# Altium schematic sheet PAGE04_飞机端BMS.SchDoc
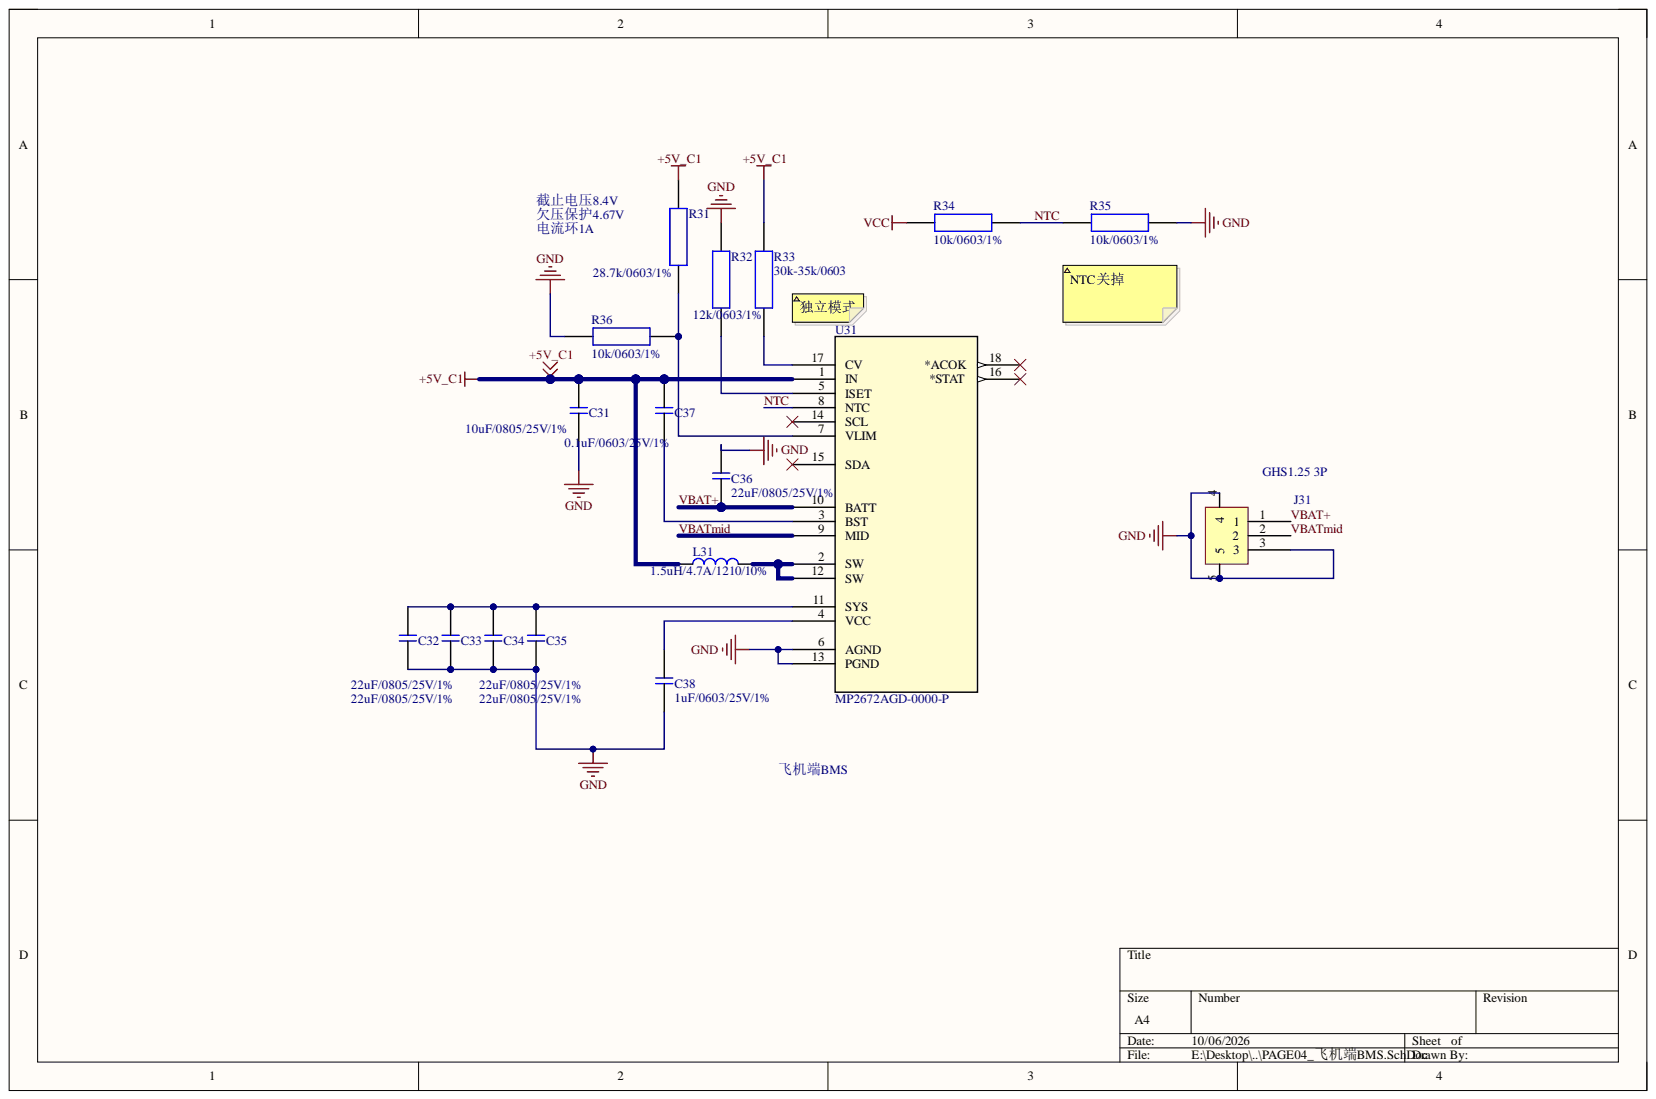
[diagram p0: PAGE04_飞机端BMS.SchDoc]

## Parts
C31  10uF/0805/25V/1%  [0603_ding_C]
C32  22uF/0805/25V/1%  [0603_ding_C]
C33  22uF/0805/25V/1%  [0603_ding_C]
C34  22uF/0805/25V/1%  [0603_ding_C]
C35  22uF/0805/25V/1%  [0603_ding_C]
C36  22uF/0805/25V/1%  [0603_ding_C]
C37  0.1uF/0603/25V/1%  [0603_ding_C]
C38  1uF/0603/25V/1%  [0603_ding_C]
J31  GHS1.25 3P  [SM03B_GHS立式]
L31  1.5uH/4.7A/1210/10%  [1210_ding_C]
R31  28.7k/0603/1%  [0603_ding_R]
R32  12k/0603/1%  [0603_ding_R]
R33  30k-35k/0603  [0603_ding_R]
R34  10k/0603/1%  [0603_ding_R]
R35  10k/0603/1%  [0603_ding_R]
R36  10k/0603/1%  [0603_ding_R]
U31  MP2672AGD-0000-P  [QFN-18_MP2672A_MNP]

## Nets
+5V_C1: C31.2 C37.2 L31.1 R31.2 R33.2 U31.1(IN)
GND: C31.1 C32.1 C33.1 C34.1 C35.1 C36.1 C38.1 J31.3 J31.4 J31.5 R32.2 R35.1 R36.2 U31.6(AGND) U31.13(PGND)
VCC: R34.2
NTC: R34.1 R35.2 U31.8(NTC)
VBAT+: C36.2 J31.1 U31.10(BATT)
VBATmid: J31.2 U31.9(MID)
NetC32_2: C32.2 C33.2 C34.2 C35.2 U31.11(SYS)
NetC37_1: C37.1 U31.3(BST)
NetC38_2: C38.2 U31.4(VCC)
NetL31_2: L31.2 U31.2(SW) U31.12(SW)
NetR31_1: R31.1 R36.1 U31.7(VLIM)
NetR32_1: R32.1 U31.5(ISET)
NetR33_1: R33.1 U31.17(CV)

Not connected: U31 14(SCL) 15(SDA) 16(*STAT) 18(*ACOK)

## Library data of the parts
C31-C38 = C: Component Kind: Standard; Library Name: IP6826.SchLib
J31 = GHS1.25 3P: 立式; Component Kind: Standard; Library Name: RobotLab_Common_Used.SchLib
L31 = L-ip6826: Component Kind: Standard; Library Name: IP6826.SchLib
R31-R36 = RES: Component Kind: Standard; Library Name: IP6826.SchLib
U31 = MP2672AGD-0000-P: 2A Boost Charger with NVDC Power Path Management and Integrated Cell Balancing for 2-Cell Li-Ion Batteries in Series; Manufacturer_Name: Monolithic Power Systems; Component Kind: Standard; Library Name: MP2672AGD-0000-P.SchLib; Manufacturer_Part_Number: MP2672AGD-0000-P; RefDes: RefDes; Type: Type; Copyright: Copyright (C) 2026 Ultra Librarian. All rights reserved.
